# Altium schematic sheet 5.04.51805A02_STMUSB.SchDoc(5.04.51805A02_STMUSB)
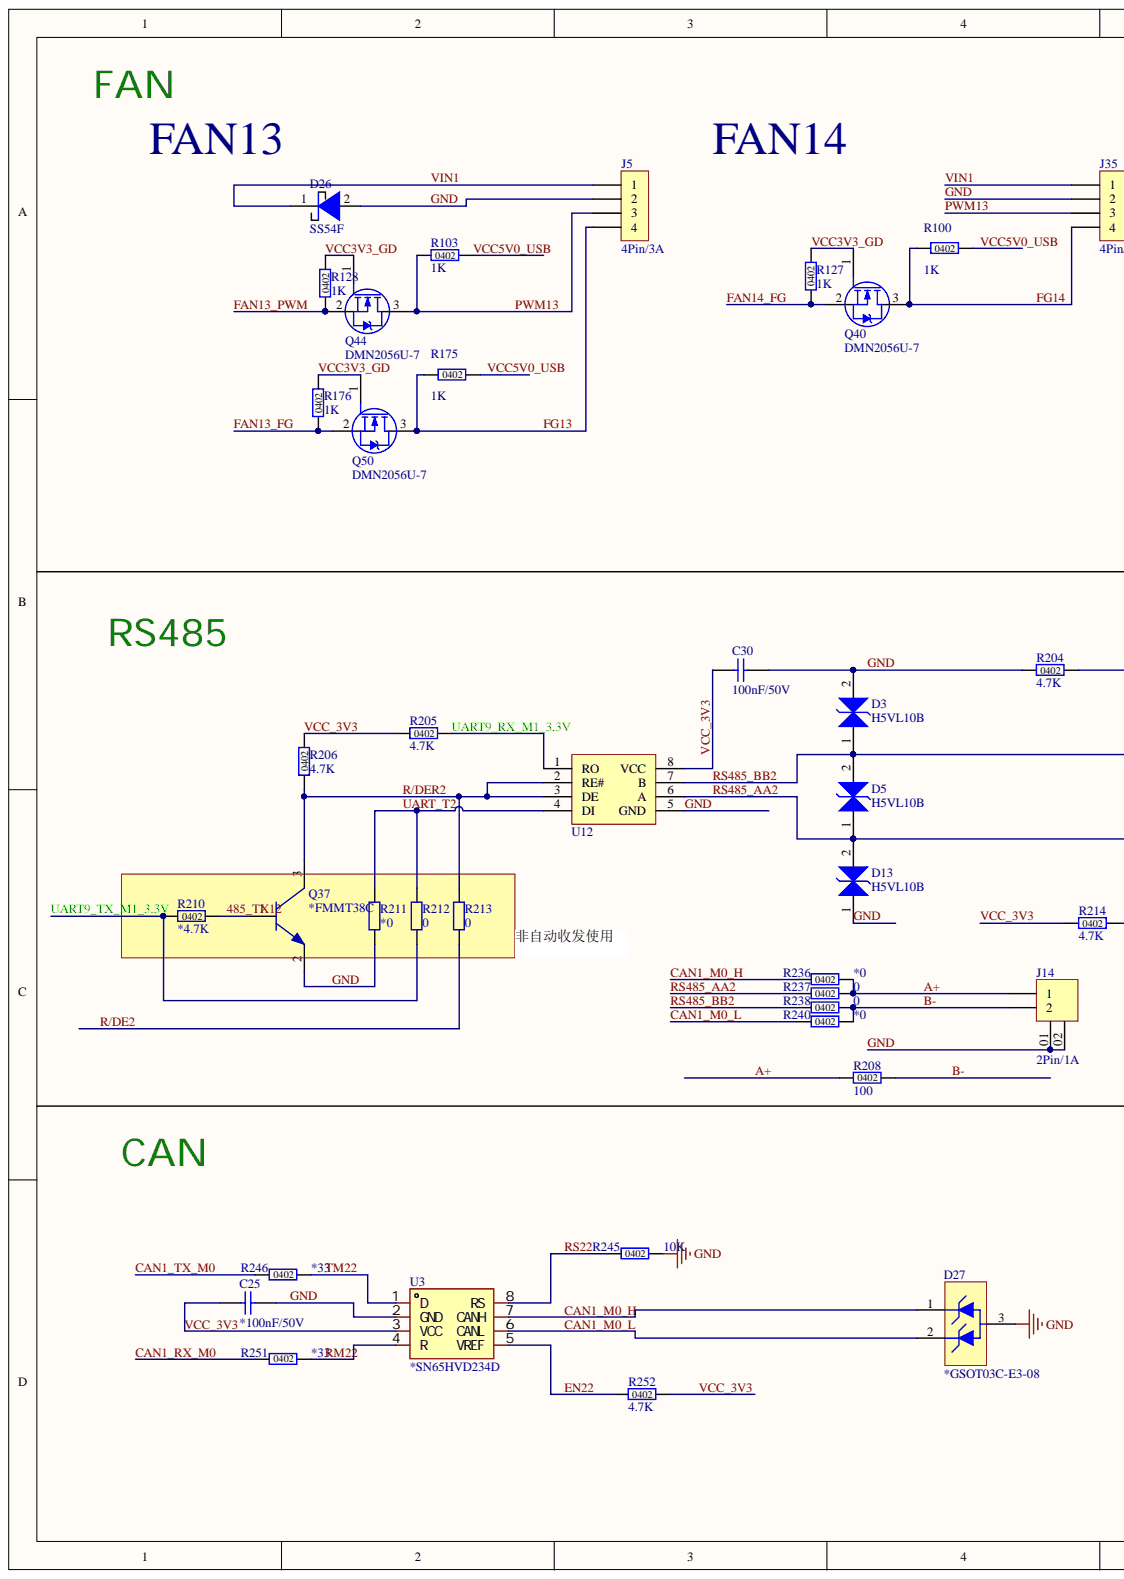
[diagram p0: 5.04.51805A02_STMUSB.SchDoc, left]
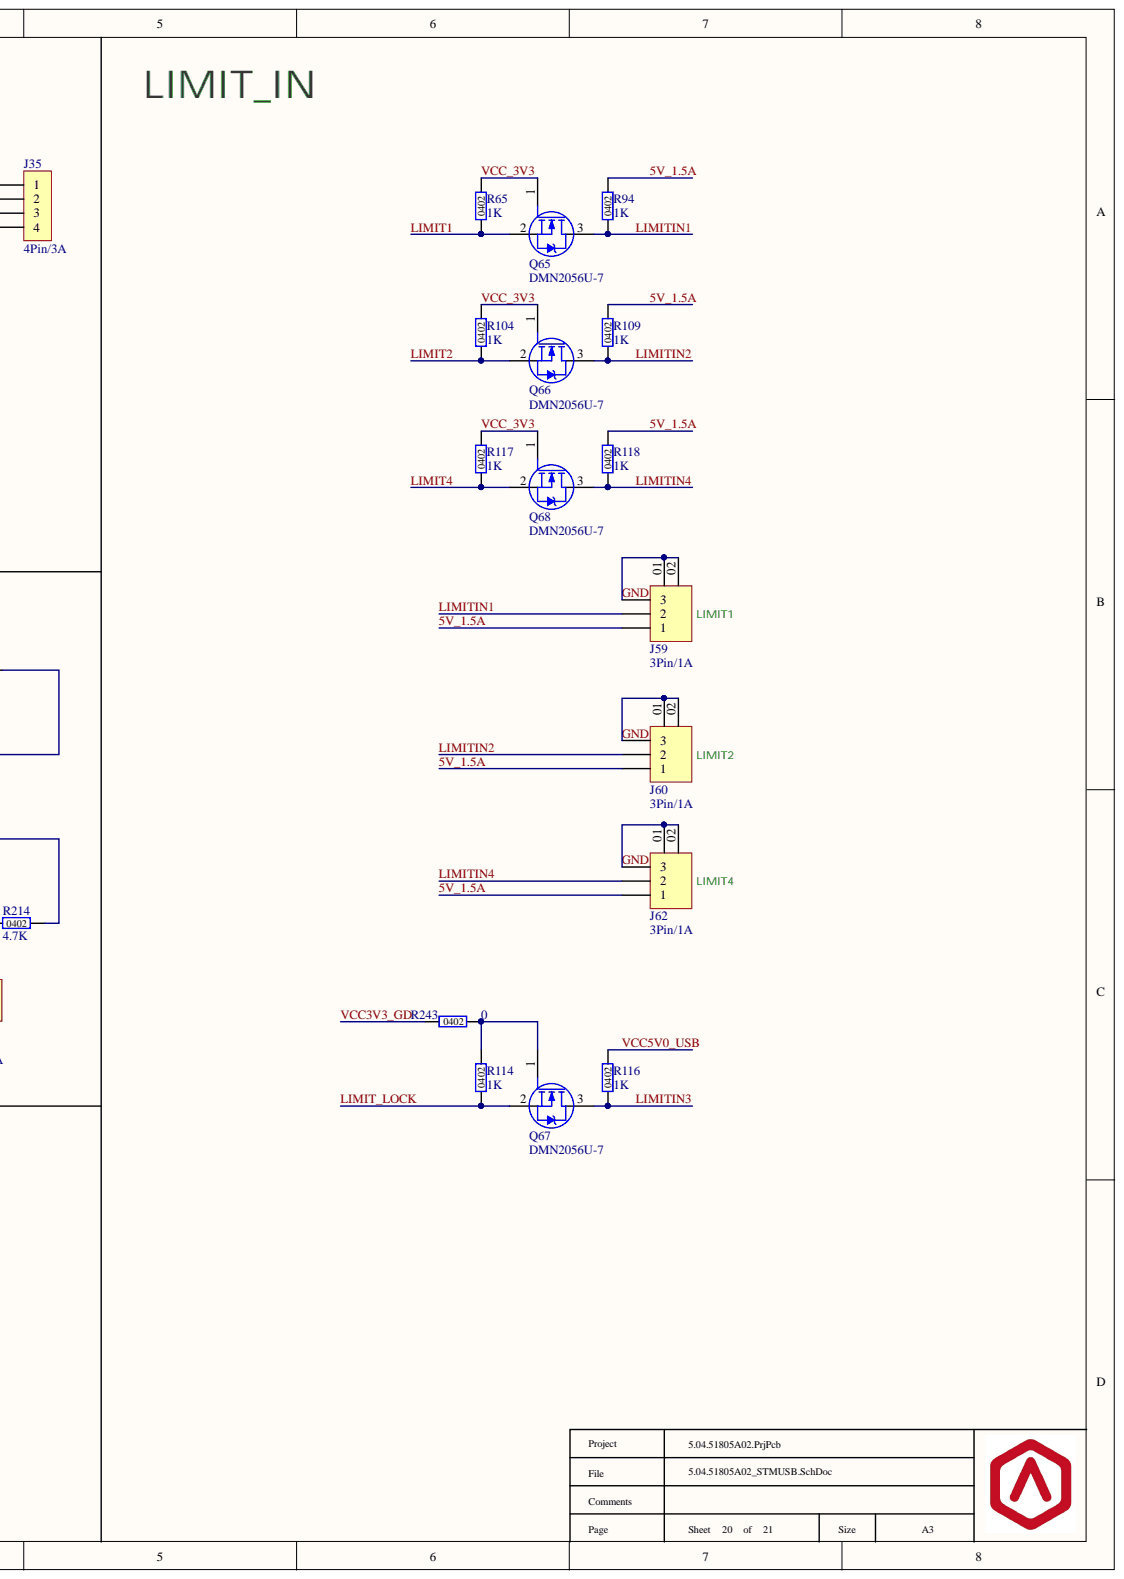
[diagram p1: 5.04.51805A02_STMUSB.SchDoc, right]

## Parts
C25  *100nF/50V  [C0402]
C30  100nF/50V  [C0402]
D3  H5VL10B  [SOD-882_L1.0-W0.6-BI]
D5  H5VL10B  [SOD-882_L1.0-W0.6-BI]
D13  H5VL10B  [SOD-882_L1.0-W0.6-BI]
D26  SS54F  [SMAF_L3.5-W2.6-LS4.7-RD]
D27  *GSOT03C-E3-08  [TVS_SOT-23-3]
J5  4Pin/3A  [B04B-PASK-1(LF)(SN)]
J14  2Pin/1A  [BM02B-GHS-TBT(LF)(SN)]
J35  4Pin/3A  [B04B-PASK-1(LF)(SN)]
J59  3Pin/1A  [BM03B-GHS-TBT(LF)(SN)]
J60  3Pin/1A  [BM03B-GHS-TBT(LF)(SN)]
J62  3Pin/1A  [BM03B-GHS-TBT(LF)(SN)]
Q37  *FMMT38C  [SOT-23-3]
Q40  DMN2056U-7  [SOT-23-3]
Q44  DMN2056U-7  [SOT-23-3]
Q50  DMN2056U-7  [SOT-23-3]
Q65  DMN2056U-7  [SOT-23-3]
Q66  DMN2056U-7  [SOT-23-3]
Q67  DMN2056U-7  [SOT-23-3]
Q68  DMN2056U-7  [SOT-23-3]
R65  1K  [R0402]
R94  1K  [R0402]
R100  1K  [R0402]
R103  1K  [R0402]
R104  1K  [R0402]
R109  1K  [R0402]
R114  1K  [R0402]
R116  1K  [R0402]
R117  1K  [R0402]
R118  1K  [R0402]
R127  1K  [R0402]
R128  1K  [R0402]
R175  1K  [R0402]
R176  1K  [R0402]
R204  4.7K  [R0402]
R205  4.7K  [R0402]
R206  4.7K  [R0402]
R208  100  [R0402]
R210  *4.7K  [R0402]
R211  *0  [R0402]
R212  0  [R0402]
R213  0  [R0402]
R214  4.7K  [R0402]
R236  *0  [R0402]
R237  0  [R0402]
R238  0  [R0402]
R240  *0  [R0402]
R243  0  [R0402]
R245  10K  [R0402]
R246  *33  [R0402]
R251  *33  [R0402]
R252  4.7K  [R0402]
U3  *SN65HVD234D  [SOP-8]
U12  MAX3485ESA+T  [SOIC-8_L4.9-W3.9-P1.27-LS6.0-BL]  (value not drawn)

## Nets
5V_1.5A: J59.1 J60.1 J62.1 R94.2 R109.2 R118.2
485_TX12: Q37.1 R210.2
A+: J14.1 R208.1 R236.2 R237.2
B-: J14.2 R208.2 R238.2 R240.2
CAN1_M0_H: D27.1 R236.1 U3.7(CANH)
CAN1_M0_L: D27.2 R240.1 U3.6(CANL)
CAN1_RX_M0: R251.1
CAN1_TX_M0: R246.1
EN22: R252.1 U3.5(VREF)
FAN13_FG: Q50.2 R176.1
FAN13_PWM: Q44.2 R128.1
FAN14_FG: Q40.2 R127.1
FG13: J5.4 Q50.3 R175.2
FG14: J35.4 Q40.3 R100.2
GND: C25.2 C30.1 D3.2 D13.1 D26.2 D27.3 J5.2 J14.01 J14.02 J35.2 J59.01 J59.02 J59.3 J60.01 J60.02 J60.3 J62.01 J62.02 J62.3 Q37.2 R204.1 R211.1 R245.2 U3.2(GND) U12.5(GND)
LIMIT1: Q65.2 R65.1
LIMIT2: Q66.2 R104.1
LIMIT4: Q68.2 R117.1
LIMIT_LOCK: Q67.2 R114.1
LIMITIN1: J59.2 Q65.3 R94.1
LIMITIN2: J60.2 Q66.3 R109.1
LIMITIN3: Q67.3 R116.1
LIMITIN4: J62.2 Q68.3 R118.1
PWM13: J5.3 J35.3 Q44.3 R103.2
R/DE2: R213.1
R/DER2: Q37.3 R206.1 R213.2 U12.2(RE#) U12.3(DE)
RM22: R251.2 U3.4(R)
RS22: R245.1 U3.8(RS)
RS485_AA2: D5.1 D13.2 R214.2 R237.1 U12.6(A)
RS485_BB2: D3.1 D5.2 R204.2 R238.1 U12.7(B)
TM22: R246.2 U3.1(D)
UART9_RX_M1_3.3V: R205.2 U12.1(RO)
UART9_TX_M1_3.3V: R210.1 R212.1
UART_T2: R211.2 R212.2 U12.4(DI)
VCC3V3_GD: Q40.1 Q44.1 Q50.1 R127.2 R128.2 R176.2 R243.1
VCC5V0_USB: R100.1 R103.1 R116.2 R175.1
VCC_3V3: C25.1 C30.2 Q65.1 Q66.1 Q68.1 R65.2 R104.2 R117.2 R205.1 R206.2 R214.1 R252.2 U3.3(VCC) U12.8(VCC)
VIN1: D26.1 J5.1 J35.1
NetQ67_1: Q67.1 R114.2 R243.2

## Library data of the parts
C25 C30 = C0402_100nF_50V: Ceramic Capacitor, 100nF, ±10%, 50V, -55°C~125°C, C0402; Manufacturer1: Yageo; ManufacturerPartNumber1: CC0402KRX7R9BB104; Code: 5191C104; Component Kind: Standard; Manufacturer2: MuRata; ManufacturerPartNumber2: GRM155R71H104KE14D
  C25: Library Name: Raise3D_Capacitor.IntLib
  C30: Library Name: Raise3D_Capacitor.SCHLIB
D3 D5 D13 = H5VL10B: TVS,H5VL10B,5V,100mA,DFN1006-2L,-55°C~125°C; Manufacturer1: R+O; Code: C7420372; Component Kind: Standard; ManufacturerPartNumber1: H5VL10B; Library Name: Raise3D_General.IntLib
D26 = SBD_SS54F: SBD,SS54F,40V,550mV,5A,SMB,-65°C~150°C; Manufacturer1: Diodes; Code: 530300XX; Component Kind: Standard; ManufacturerPartNumber1: SS54F; Library Name: Raise3D_Diode.IntLib
D27 = TVS_GSOT03C-E3-08: TVS二极管,GSOT03C-E3-08,3.3V,2Channel,+/-30kV,+/-30kV,SOT-23-3,-40°C~125°C; Manufacturer1: Vishay; Code: 53040012; Component Kind: Standard; ManufacturerPartNumber1: GSOT03C-E3-08; Library Name: Raise3D_Diode.IntLib
J5 J35 = JW_B04B-PASK-1(LF)(SN): 线对板连接器,4PINS,Pitch2mm,TH,OR,3A,白,-25°C~85°C; Manufacturer1: JST; Code: 55010010; Component Kind: Standard; Manufacturer2: 红星电业（HXH）; ManufacturerPartNumber1: B04B-PASK-1(LF)(SN); ManufacturerPartNumber2: HX20018-4A/BH; Library Name: Raise3D_Connector.IntLib
J14 = JW_BM02B-GHS-TBT(LF)(SN): 线对板连接器,2PINS,Pitch1.25mm,SMT,OR,1A,米黄,-40°C~85°C; Manufacturer1: JST; Code: 55010001; Component Kind: Standard; Manufacturer2: 健坤; ManufacturerPartNumber1: BM02B-GHS-TBT(LF)(SN); ManufacturerPartNumber2: A1253AWV-02-F1CB1-P; Library Name: Raise3D_Connector.IntLib
J59 J60 J62 = JW_BM03B-GHS-TBT(LF)(SN): 线对板连接器,3PINS,Pitch1.25mm,SMT,OR,1A,米黄,-40°C~85°C; Manufacturer1: JST; Code: 55010002; Component Kind: Standard; Manufacturer2: 健坤; ManufacturerPartNumber1: BM03B-GHS-TBT(LF)(SN); ManufacturerPartNumber2: A1253AWV-03-F1CB1-P; Library Name: Raise3D_Connector.IntLib
Q37 = NPN_FMMT38C: BJT，NPN, FMMT38C, 1Channel, Vceo=60V, Ic=300mA, SOT-23-3,-55℃~150℃; Manufacturer1: DIODES; Code: 54030002; Component Kind: Standard; ManufacturerPartNumber1: FMMT38C; Library Name: Raise3D_MOS&BJT.IntLib
Q40 Q44 Q50 Q65-Q68 = NMOS_DMN2056U-7: N-MOSFET,DMN2056U-7,1Channel,Vds=20V,Id=4A,Vgs=+/-8V,TO-236-3,-55°C~150°C; Manufacturer1: DIODES; Code: 54010011; Component Kind: Standard; ManufacturerPartNumber1: DMN2056U-7
  Q40 Q50 Q65-Q68: Library Name: Raise3D_MOS&BJT.IntLib
  Q44: Library Name: Raise3D_MOS&BJT.SCHLIB
R65 R94 R100 R103 R104 R109 R114 R116-R118 R127 R128 R175 R176 = R0402_1K: Resistor 1Kohm,5%,1/16W,R0402; Manufacturer1: Yageo; ManufacturerPartNumber1: RC0402JR-071KL; Code: 5011001K; Component Kind: Standard
  R65 R94 R104 R109 R114 R116-R118: Library Name: Raise3D_Resistor.SCHLIB
  R100 R103 R127 R128 R175 R176: Library Name: Raise3D_Resistor.IntLib
R204-R206 R210 R214 R252 = R0402_4.7K: Resistor 4.7Kohm,5%,1/16W,R0402; Manufacturer1: Yageo; ManufacturerPartNumber1: RC0402JR-074K7L; Code: 501104K7; Component Kind: Standard; Manufacturer2: Samsung; ManufacturerPartNumber2: RCS1005J472CS; Library Name: Raise3D_Resistor.IntLib
R208 = R0402_100: Resistor 100ohm,1%,1/16W,R0402; Manufacturer1: Yageo; ManufacturerPartNumber1: RC0402FR-07100RL; Code: 5001100R; Component Kind: Standard; Manufacturer2: Samsung; ManufacturerPartNumber2: RC1005F101CS; Library Name: Raise3D_Resistor.IntLib
R211-R213 R236-R238 R240 R243 = R0402_0: Resistor 0ohm,1%,1/16W,R0402; Manufacturer1: Yageo; ManufacturerPartNumber1: RC0402FR-070RL; Code: 5001000R; Component Kind: Standard
  R211-R213: Library Name: Raise3D_Resistor.SCHLIB
  R236-R238 R240 R243: Library Name: Raise3D_Resistor.IntLib
R245 = R0402_10K: Resistor 10Kohm,1%,1/16W,R0402; Manufacturer1: Yageo; ManufacturerPartNumber1: RC0402FR-0710KL; Code: 5001010K; Component Kind: Standard; Manufacturer2: Samsung; ManufacturerPartNumber2: RC1005F103CS; Library Name: Raise3D_Resistor.IntLib
R246 R251 = R0402_33: Resistor 33ohm,1%,1/16W,R0402; Manufacturer1: Yageo; ManufacturerPartNumber1: RC0402FR-0733RL; Code: 5001033R; Component Kind: Standard; Library Name: Raise3D_Resistor.IntLib
U3 = DRV_SN65HVD234D: 接口IC,SN65HVD234D,CAN,1Port(s),3 ~ 3.6V,8-SOIC,-40°C~125°C; Manufacturer1: TexasInstruments; Code: 59080005; Component Kind: Standard; ManufacturerPartNumber1: SN65HVD234D; Library Name: Raise3D_IC.IntLib
U12 = MAX3485ESA+T: RS485/422芯片,3.3V,1ports,-40℃~85℃,SOP-8; Manufacturer1: JSMSEMI; Code: C22447116; Component Kind: Standard; Manufacturer2: AD; ManufacturerPartNumber1: MAX3485ESA+T; ManufacturerPartNumber2: MAX3485ESA+T; Library Name: Raise3D_General.IntLib
